# Altium schematic sheet HAB PCB V2.SchDoc
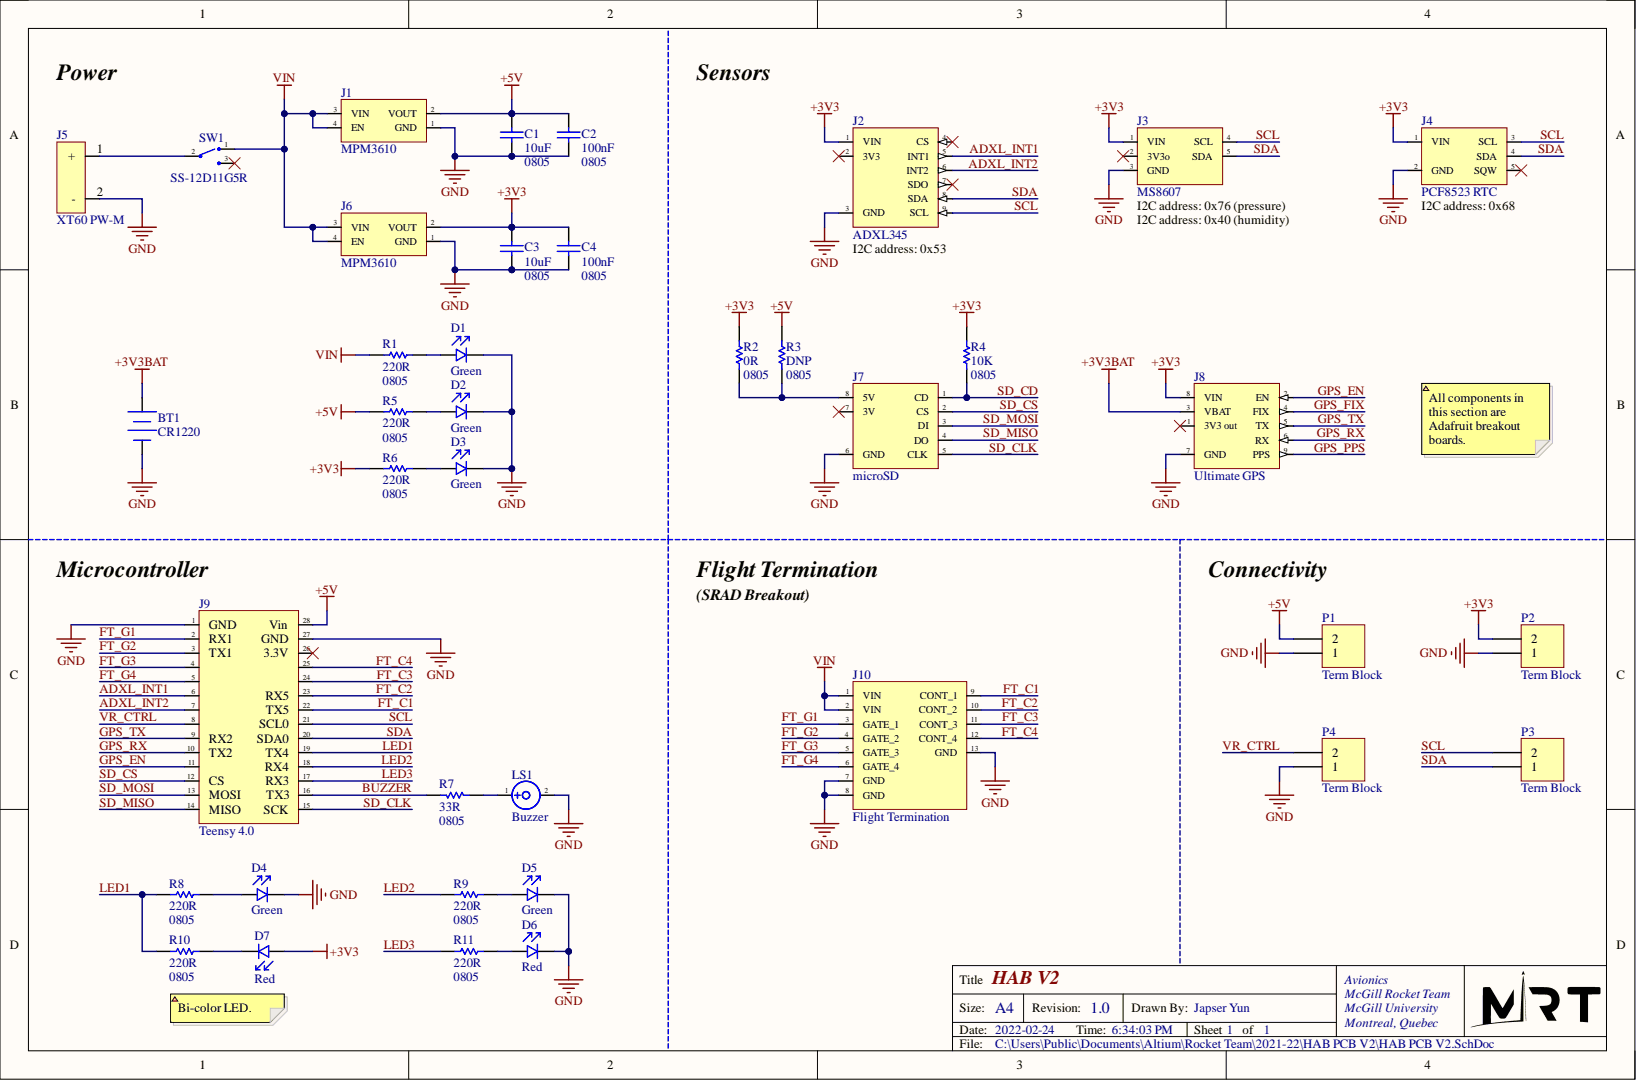
[diagram p0: HAB PCB V2.SchDoc]

## Parts
BT1  CR1220  [Keystone 3000 CR1220 Battery Coin Cell holder]
C1  10uF  value 0805  [0805 CAP]
C2  100nF  value 0805  [0805 CAP]
C3  10uF  value 0805  [0805 CAP]
C4  100nF  value 0805  [0805 CAP]
D1  Green  [0805 LED]
D2  Green  [0805 LED]
D3  Green  [0805 LED]
D4  Green  [0805 LED]
D5  Green  [0805 LED]
D6  Red  [0805 LED]
D7  Red  [0805 LED]
J1  MPM3610  [Breakout MPM3610 Buck Converter]
J2  ADXL345  [Breakout ADXL345 Adafruit]
J3  MS8607  [Breakout MS8607 Adafruit]
J4  PCF8523 RTC  [Breakout PCF8523 RTC Qwiic]
J5  XT60 PW-M  [Connector XT60PW-M]
J6  MPM3610  [Breakout MPM3610 Buck Converter]
J7  microSD  [BREAKOUT MICROSD]
J8  Ultimate GPS  [Breakout Adafruit Ultimate GPS]
J9  Teensy 4.0  [Teensy 4.0]
J10  Flight Termination  [Flight Termination PCB V1]
LS1  Buzzer  [Buzzer CMT-9648-85T]
P1  Term Block  [Terminal Block 1935161 2-pos]
P2  Term Block  [Terminal Block 1935161 2-pos]
P3  Term Block  [Terminal Block 1935161 2-pos]
P4  Term Block  [Terminal Block 1935161 2-pos]
R1  220R  value 0805  [0805 RES]
R2  0R  value 0805  [0805 RES]
R3  DNP  value 0805  [0805 RES]
R4  10K  value 0805  [0805 RES]
R5  220R  value 0805  [0805 RES]
R6  220R  value 0805  [0805 RES]
R7  33R  value 0805  [0805 RES]
R8  220R  value 0805  [0805 RES]
R9  220R  value 0805  [0805 RES]
R10  220R  value 0805  [0805 RES]
R11  220R  value 0805  [0805 RES]
SW1  SS-12D11G5R  [Switch SPST SS-12D11G5R]

## Nets
+3V3: C3.2 C4.2 D7.1 J2.1(VIN) J3.1(VIN) J4.1(VIN) J6.2(VOUT) J8.8(VIN) P2.2 R2.2 R4.2 R6.2
+3V3BAT: BT1.1 J8.3(VBAT)
+5V: C1.2 C2.2 J1.2(VOUT) J9.28(Vin) P1.2 R3.2 R5.2
GND: BT1.2 C1.1 C2.1 C3.1 C4.1 D1.2 D2.2 D3.2 D4.2 D5.2 D6.2 J1.1(GND) J2.3(GND) J3.3(GND) J4.2(GND) J5.2 J6.1(GND) J7.6(GND) J8.7(GND) J9.1(GND) J9.27(GND) J10.7(GND) J10.8(GND) J10.13(GND) LS1.2 P1.1 P2.1 P4.1
VIN: J1.3(VIN) J1.4(EN) J6.3(VIN) J6.4(EN) J10.1(VIN) J10.2(VIN) R1.2 SW1.1
ADXL_INT1: J2.5(INT1) J9.6
ADXL_INT2: J2.6(INT2) J9.7
BUZZER: J9.16(TX3) R7.1
FT_C1: J9.22(TX5) J10.9(CONT_1)
FT_C2: J9.23(RX5) J10.10(CONT_2)
FT_C3: J9.24 J10.11(CONT_3)
FT_C4: J9.25 J10.12(CONT_4)
FT_G1: J9.2(RX1) J10.3(GATE_1)
FT_G2: J9.3(TX1) J10.4(GATE_2)
FT_G3: J9.4 J10.5(GATE_3)
FT_G4: J9.5 J10.6(GATE_4)
GPS_EN: J8.2(EN) J9.11
GPS_FIX: J8.4(FIX)
GPS_PPS: J8.9(PPS)
GPS_RX: J8.6(RX) J9.10(TX2)
GPS_TX: J8.5(TX) J9.9(RX2)
LED1: J9.19(TX4) R8.2 R10.2
LED2: J9.18(RX4) R9.2
LED3: J9.17(RX3) R11.2
SCL: J2.9(SCL) J3.4(SCL) J4.3(SCL) J9.21(SCL0) P3.2
SD_CD: J7.1(CD) R4.1
SD_CLK: J7.5(CLK) J9.15(SCK)
SD_CS: J7.2(CS) J9.12(CS)
SD_MISO: J7.4(DO) J9.14(MISO)
SD_MOSI: J7.3(DI) J9.13(MOSI)
SDA: J2.8(SDA) J3.5(SDA) J4.4(SDA) J9.20(SDA0) P3.1
VR_CTRL: J9.8 P4.2
NetD1_1: D1.1 R1.1
NetD2_1: D2.1 R5.1
NetD3_1: D3.1 R6.1
NetD4_1: D4.1 R8.1
NetD5_1: D5.1 R9.1
NetD6_1: D6.1 R11.1
NetD7_2: D7.2 R10.1
NetJ5_1: J5.1 SW1.2
NetJ7_8: J7.8(5V) R2.1 R3.1
NetLS1_1: LS1.1 R7.2

Not connected: J2 2(3V3) 4(CS) 7(SDO); J3 2(3V3o); J4 5(SQW); J7 7(3V); J8 1(3V3_out); J9 26(3.3V); SW1 3

## Library data of the parts
BT1 = Battery: Keystone 3000 for CR1220 coin cell; Component Kind: Standard; LatestRevisionDate: 17-Jul-2002; LatestRevisionNote: Re-released for DXP Platform.; Library Name: Miscellaneous Devices.IntLib; PackageDescription: Battery; 2 Leads; PackageReference: BAT-2; Published: 24-Mar-1999; Publisher: Altium Limited
C1-C4 = Cap: Capacitor; Component Kind: Standard; LatestRevisionDate: 17-Jul-2002; LatestRevisionNote: Re-released for DXP Platform.; Library Name: Miscellaneous Devices.IntLib; PackageDescription: Radial Cap, Thru-Hole; 2 Leads; 0.3 in Pin Spacing; PackageReference: RAD-0.3; Published: 8-Jun-2000; Signal Integrity: Cap; Simulation: CAP; Publisher: Altium Limited
D1-D7 = LED: Generic LED; Component Kind: Standard; Library Name: MRT SCH Library.SchLib
J1 J6 = Breakout MPM3610 Buck Converter: Adafruit MPM3610 Buck Converter breakout. Same footprint for different 5V and 3.3V versions.; Component Kind: Standard; Library Name: MRT SCH Library.SchLib
J2 = Breakout ADXL345 3-axis Accelerometer: Adafruit ADXL345 breakout (product: 1231); Component Kind: Standard; Library Name: MRT SCH Library.SchLib
J3 = Breakout MS8607 PHT Sensor: Adafruit MS8607 breakout (product ID 4716); Component Kind: Standard; Library Name: MRT SCH Library.SchLib
J4 = Breakout PCF8523 RTC (Qwiic): Adafruit PCF8523 RTC Breakout (Qwiic) (product: 5189); Component Kind: Standard; Library Name: MRT SCH Library.SchLib
J7 = Breakout microSD: Adafruit microSD card breakout board+ (product: 254); Component Kind: Standard; Library Name: MRT SCH Library.SchLib
J8 = Breakout Adafruit Ultimate GPS: Adafruit Ultimate GPS (product: 746); Component Kind: Standard; Library Name: MRT SCH Library.SchLib
J10 = Flight Termination Board V1: Component Kind: Standard; Library Name: HAB PCB V2.SchLib
LS1 = Buzzer HNB09A05: CMT-9648-85T  Magnetic buzzer; Component Kind: Standard; Library Name: MRT SCH Library.SchLib
P1-P4 = Header 2: 1935161 Phoenix Contact; Component Kind: Standard; LatestRevisionDate: 17-Jul-2002; LatestRevisionNote: Re-released for DXP Platform.; Library Name: Miscellaneous Connectors.IntLib; Signal Integrity: Connector; Publisher: Altium Limited
R1 R8 R9 = Res1: 0805; Value: 0805; Component Kind: Standard; LatestRevisionDate: 17-Jul-2002; LatestRevisionNote: Re-released for DXP Platform.; Library Name: Miscellaneous Devices.IntLib; PackageDescription: Axial Device, Thru-Hole; 2 Leads; 0.3 in Pin Spacing; PackageReference: AXIAL-0.3; Published: 8-Jun-2000; Signal Integrity: Res; Simulation: RESISTOR; Publisher: Altium Limited
R2-R7 R10 R11 = Res1: 0805; Component Kind: Standard; LatestRevisionDate: 17-Jul-2002; LatestRevisionNote: Re-released for DXP Platform.; Library Name: Miscellaneous Devices.IntLib; PackageDescription: Axial Device, Thru-Hole; 2 Leads; 0.3 in Pin Spacing; PackageReference: AXIAL-0.3; Published: 8-Jun-2000; Signal Integrity: Res; Simulation: RESISTOR; Publisher: Altium Limited
SW1 = Switch SS-12D11G5R: Component Kind: Standard; Library Name: MRT SCH Library.SchLib
J5 = XT60 PW-M: Component Kind: Standard; Library Name: MRT SCH Library.SchLib
J9 = Teensy 4.0: Component Kind: Standard; Library Name: MRT SCH Library.SchLib
